# Altium schematic sheet sheet1.SchDoc
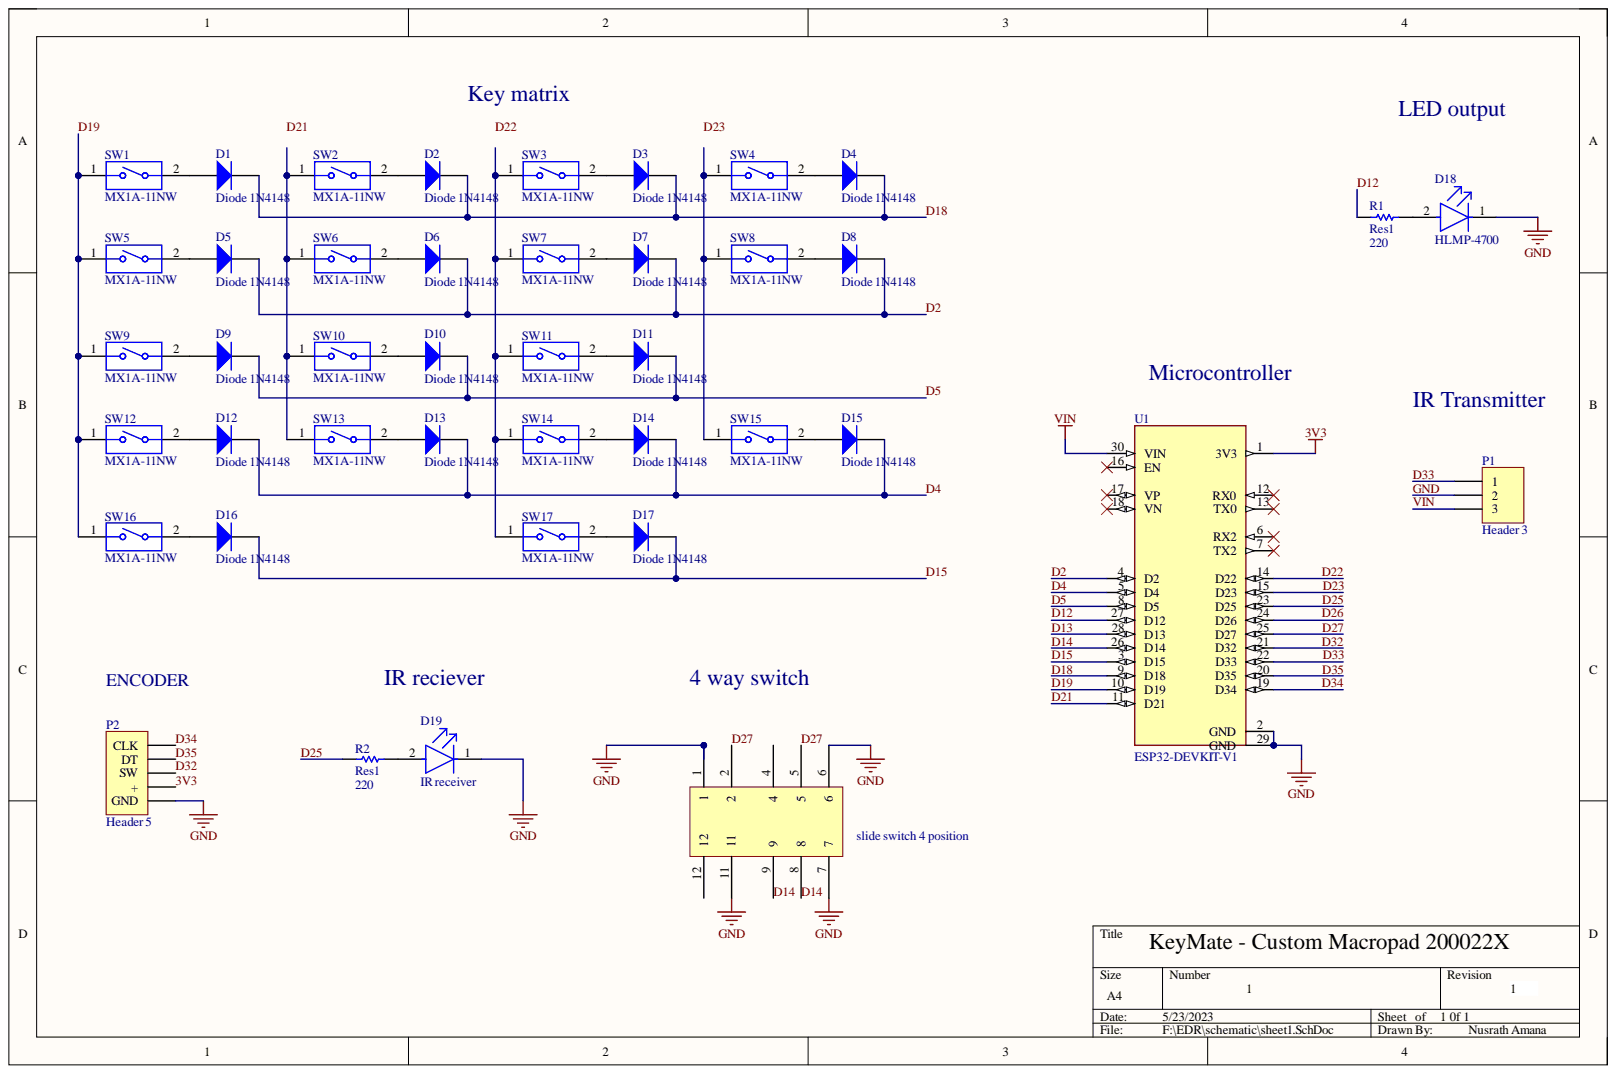
[diagram p0: sheet1.SchDoc]

## Parts
*1  slide switch 4 position  [SWSLIDT_DP4T_S_20X8]  (part B)
D1  Diode 1N4148  [DO-35]
D2  Diode 1N4148  [DO-35]
D3  Diode 1N4148  [DO-35]
D4  Diode 1N4148  [DO-35]
D5  Diode 1N4148  [DO-35]
D6  Diode 1N4148  [DO-35]
D7  Diode 1N4148  [DO-35]
D8  Diode 1N4148  [DO-35]
D9  Diode 1N4148  [DO-35]
D10  Diode 1N4148  [DO-35]
D11  Diode 1N4148  [DO-35]
D12  Diode 1N4148  [DO-35]
D13  Diode 1N4148  [DO-35]
D14  Diode 1N4148  [DO-35]
D15  Diode 1N4148  [DO-35]
D16  Diode 1N4148  [DO-35]
D17  Diode 1N4148  [DO-35]
D18  HLMP-4700  [FP-HLMP-4700-MFG]
D19  IR receiver  [FP-SFH_4550-MFG]
P1  Header 3  [HDR1X3]
P2  Header 5  [HDR1X5]
R1  Res1  value 220  [AXIAL-0.3]
R2  Res1  value 220  [AXIAL-0.3]
SW1  MX1A-11NW  [CHRY-MX1A-11NW_V]
SW2  MX1A-11NW  [CHRY-MX1A-11NW_V]
SW3  MX1A-11NW  [CHRY-MX1A-11NW_V]
SW4  MX1A-11NW  [CHRY-MX1A-11NW_V]
SW5  MX1A-11NW  [CHRY-MX1A-11NW_V]
SW6  MX1A-11NW  [CHRY-MX1A-11NW_V]
SW7  MX1A-11NW  [CHRY-MX1A-11NW_V]
SW8  MX1A-11NW  [CHRY-MX1A-11NW_V]
SW9  MX1A-11NW  [CHRY-MX1A-11NW_V]
SW10  MX1A-11NW  [CHRY-MX1A-11NW_V]
SW11  MX1A-11NW  [CHRY-MX1A-11NW_V]
SW12  MX1A-11NW  [CHRY-MX1A-11NW_V]
SW13  MX1A-11NW  [CHRY-MX1A-11NW_V]
SW14  MX1A-11NW  [CHRY-MX1A-11NW_V]
SW15  MX1A-11NW  [CHRY-MX1A-11NW_V]
SW16  MX1A-11NW  [CHRY-MX1A-11NW_V]
SW17  MX1A-11NW  [CHRY-MX1A-11NW_V]
U1  ESP32-DEVKIT-V1  [MODULE_ESP32_DEVKIT_V1]

## Nets
3V3: P2.2(+) U1.1(3V3)
D2: D5.2 D6.2 D7.2 D8.2 U1.4(D2)
D4: D12.2 D13.2 D14.2 D15.2 U1.5(D4)
D5: D9.2 D10.2 D11.2 U1.8(D5)
D12: R1.1 U1.27(D12)
D13: U1.28(D13)
D14: *1.8 *1.9 U1.26(D14)
D15: D16.2 D17.2 U1.3(D15)
D18: D1.2 D2.2 D3.2 D4.2 U1.9(D18)
D19: SW1.1 SW5.1 SW9.1 SW12.1 SW16.1 U1.10(D19)
D21: U1.11(D21)
D22: U1.14(D22)
D23: U1.15(D23)
D25: R2.1 U1.23(D25)
D26: U1.24(D26)
D27: *1.2 *1.5 U1.25(D27)
D32: P2.3(SW) U1.21(D32)
D33: P1.1 U1.22(D33)
D34: P2.5(CLK) U1.19(D34)
D35: P2.4(DT) U1.20(D35)
GND: *1.1 *1.6 *1.7 *1.11 D18.1 D19.1 P1.2 P2.1(GND) U1.2(GND) U1.29(GND)
VIN: P1.3 U1.30(VIN)
NetD1_1: D1.1 SW1.2
NetD2_1: D2.1 SW2.2
NetD3_1: D3.1 SW3.2
NetD4_1: D4.1 SW4.2
NetD5_1: D5.1 SW5.2
NetD6_1: D6.1 SW6.2
NetD7_1: D7.1 SW7.2
NetD8_1: D8.1 SW8.2
NetD9_1: D9.1 SW9.2
NetD10_1: D10.1 SW10.2
NetD11_1: D11.1 SW11.2
NetD12_1: D12.1 SW12.2
NetD13_1: D13.1 SW13.2
NetD14_1: D14.1 SW14.2
NetD15_1: D15.1 SW15.2
NetD16_1: D16.1 SW16.2
NetD17_1: D17.1 SW17.2
NetD18_2: D18.2 R1.2
NetD19_2: D19.2 R2.2
NetSW2_1: SW2.1 SW6.1 SW10.1 SW13.1
NetSW3_1: SW3.1 SW7.1 SW11.1 SW14.1 SW17.1
NetSW4_1: SW4.1 SW8.1 SW15.1

Not connected: *1 4 12; U1 6(RX2) 7(TX2) 12(RX0) 13(TX0) 16(EN) 17(VP) 18(VN)

## Library data of the parts
D1-D17 = Diode 1N4148: High Conductance Fast Diode; Code_JEDEC: DO-204-AH; Component Kind: Standard; ComponentLink1Description: Manufacturer Link; ComponentLink1URL: http://www.fairchildsemi.com/; ComponentLink2Description: Datasheet; ComponentLink2URL: http://www.fairchildsemi.com/ds/1N%2F1N4148.pdf; DatasheetVersion: 1997; LatestRevisionDate: 17-Jul-2002; LatestRevisionNote: Re-released for DXP Platform.; Library Name: Miscellaneous Devices.IntLib; PackageDescription: Diode, Axial; Body 3.8 x 1.9 mm (LxDia. max), Lead Dia. 0.53 mm (max); PackageReference: DO-35; Published: 8-Jun-2000; Signal Integrity: 1N4148; Simulation: 1N4148; Publisher: Altium Limited
D18 = CMP-18399-000008-1: LED RED DIFFUSED T-1 3/4 T/H; Manufacturer: Broadcom; Forward Current: 2mA; Forward Voltage: 1.7V; Led Orientation: Straight; Luminous Intensity: 2.3mcd; Peak Emmision Wavelength: 635nm; Power Dissipation: 14mW; Reverse Voltage: 5V; Viewing Angle: 50°; Wavelength: 626nm; Automotive: No; Category: Diode; Colour: Red; Component Kind: Standard; ComponentLink2Description: Datasheet; ComponentLink2URL: https://docs.broadcom.com/docs/AV02-1557EN; Device Class L1: Optoelectronics; Device Class L2: LEDs; Device Class L3: unset; Digikey Description: LED RED DIFFUSED T-1 3/4 T/H; Lead Free: Yes; Library Name: Altium Content Vault; Manufacturer Part Number: HLMP-4700; Mouser Description: LED; Red; 2.3mcd; 0.24In.Dia.; T-1-3/4; 500mA; 1.7V; 635nm; 50deg; 5V; 24mW; -55degC;; Octopart: https://octopart.com/hlmp-4700-broadcom-71130526; Package: HLMP-4700; RoHS: TRUE; Temperature: -40°C to +100°C; Temperature Range High: +100°C; Temperature Range Low: -40°C
D19 = CMP-62016-000011-1: EMITTER IR 860NM 100MA RADIAL; Manufacturer: Osram Opto; Colour: Infrared; Forward Voltage: 1.5V; Led Orientation: Straight; Reverse Voltage: 5V; Viewing Angle: 6°; Automotive: No; Category: Diode; Component Kind: Standard; ComponentLink2Description: Datasheet; ComponentLink2URL: https://dammedia.osram.info/media/resource/hires/osram-dam-5580407/SFH%204550_EN.pdf; Device Class L1: Optoelectronics; Device Class L2: LEDs; Device Class L3: unset; Digikey Description: EMITTER IR 860NM 100MA RADIAL; Forward Current: 100mA; Lead Free: Yes; Library Name: Altium Content Vault; Manufacturer Part Number: SFH 4550; Mouser Description: Infrared Emitters - High Power Infrared 850 nm HALF ANGLE +/-3DEG; Octopart: https://octopart.com/sfh+4550-osram+opto-55410894; Package: SFH 4550; Peak Emmision Wavelength: 860nm; RoHS: TRUE; Temperature: -40°C to +100°C; Temperature Range High: +100°C; Temperature Range Low: -40°C
P1 = Header 3: Header, 3-Pin; Component Kind: Standard; LatestRevisionDate: 17-Jul-2002; LatestRevisionNote: Re-released for DXP Platform.; Library Name: Miscellaneous Connectors.IntLib; Signal Integrity: Connector; Publisher: Altium Limited
P2 = Header 5: Header, 5-Pin; Component Kind: Standard; LatestRevisionDate: 17-Jul-2002; LatestRevisionNote: Re-released for DXP Platform.; Library Name: Miscellaneous Connectors.IntLib; Signal Integrity: Connector; Publisher: Altium Limited
R1 R2 = Res1: Resistor; Component Kind: Standard; LatestRevisionDate: 17-Jul-2002; LatestRevisionNote: Re-released for DXP Platform.; Library Name: Miscellaneous Devices.IntLib; PackageDescription: Axial Device, Thru-Hole; 2 Leads; 0.3 in Pin Spacing; PackageReference: AXIAL-0.3; Published: 8-Jun-2000; Signal Integrity: Res; Simulation: RESISTOR; Publisher: Altium Limited
SW1-SW17 = CMP-2000-06786-1: Keylock Switch, 12 V, -10 to 70 degC, 2-Pin THD, RoHS, Bulk; Manufacturer: Cherry; Part No: MX1A11NW; Actuator Length: 3.6mm; Actuator Style: Button; Body Orientation: Straight; COO: UK; Electrical Life: 50000000Cycle; Illumination Color: N/R; Maximum AC Voltage Rating: 12V; Maximum Contact Resistance: 200mOhm; Maximum Current Rating: 0.01A; Minimum Insulation Resistance: 100MOhm; Operating Force: 0.58N; Pole Throw Configuration: SPST; Row Spacing: 6.35mm; Switch Configuration: N.O.; Total Travel: 4.06mm; Actuator Color: N/A; Actuator Material: N/A; Component Kind: Standard; Contact Material: Silver/Gold; Contact Plating: N/A; Datasheet URL: https://cdn.sparkfun.com/datasheets/Components/Switches/MX%20Series.pdf; Datasheet Version: 09/2000; Dielectric Strength: N/A; Dimension: 15.6x15.6x15.2mm; ECCN: EAR99; Family: MX; Housing Material: N/A; HTS: 8536509065; Illumination Type: N/R; IP Rating: N/A; Library Name: Altium Content Vault; Manufacturer URL: http://cherryswitches.com/cn/; Maximum DC Voltage Rating: 12V; Maximum Power Rating: N/A; Mechanical Life: N/A; Mounting: ThroughHole; Mounting Technology: ThroughHole; Number of Terminals: 2; Operating Speed: N/A; Operating Temperature: -10to70C; Package Description: 2-Pin Through Hole Device, Body 15.6 x 15.6 mm; Package Reference: MX1A-11NW; Packaging: N/A; RoHS: Unknown; Supplier: CherryElectrical; Terminal Pitch: 2.54mm; Terminal Type: PCPins; Type: DesktopProfile
U1 = ESP32-DEVKIT-V1: Dual core, Wi-Fi: 2.4 GHz up to 150 Mbits/s,BLE (Bluetooth Low Energy) and legacy Bluetooth, 32 bits, Up to 240 MHz; MF: Do it; Availability: Not in stock; Check_prices: https://www.snapeda.com/parts/ESP32-DEVKIT-V1/Do+it/view-part/?ref=eda; Component Kind: Standard; MP: ESP32-DEVKIT-V1; Package: None; Price: None; SnapEDA_Link: https://www.snapeda.com/parts/ESP32-DEVKIT-V1/Do+it/view-part/?ref=snap; Library Name: ESP32-DEVKIT-V1 -modified.IntLib
*1 = slide switch 4 position: Component Kind: Standard; Library Name: switch.SchLib
